# Altium schematic sheet VersaVIS.SchDoc
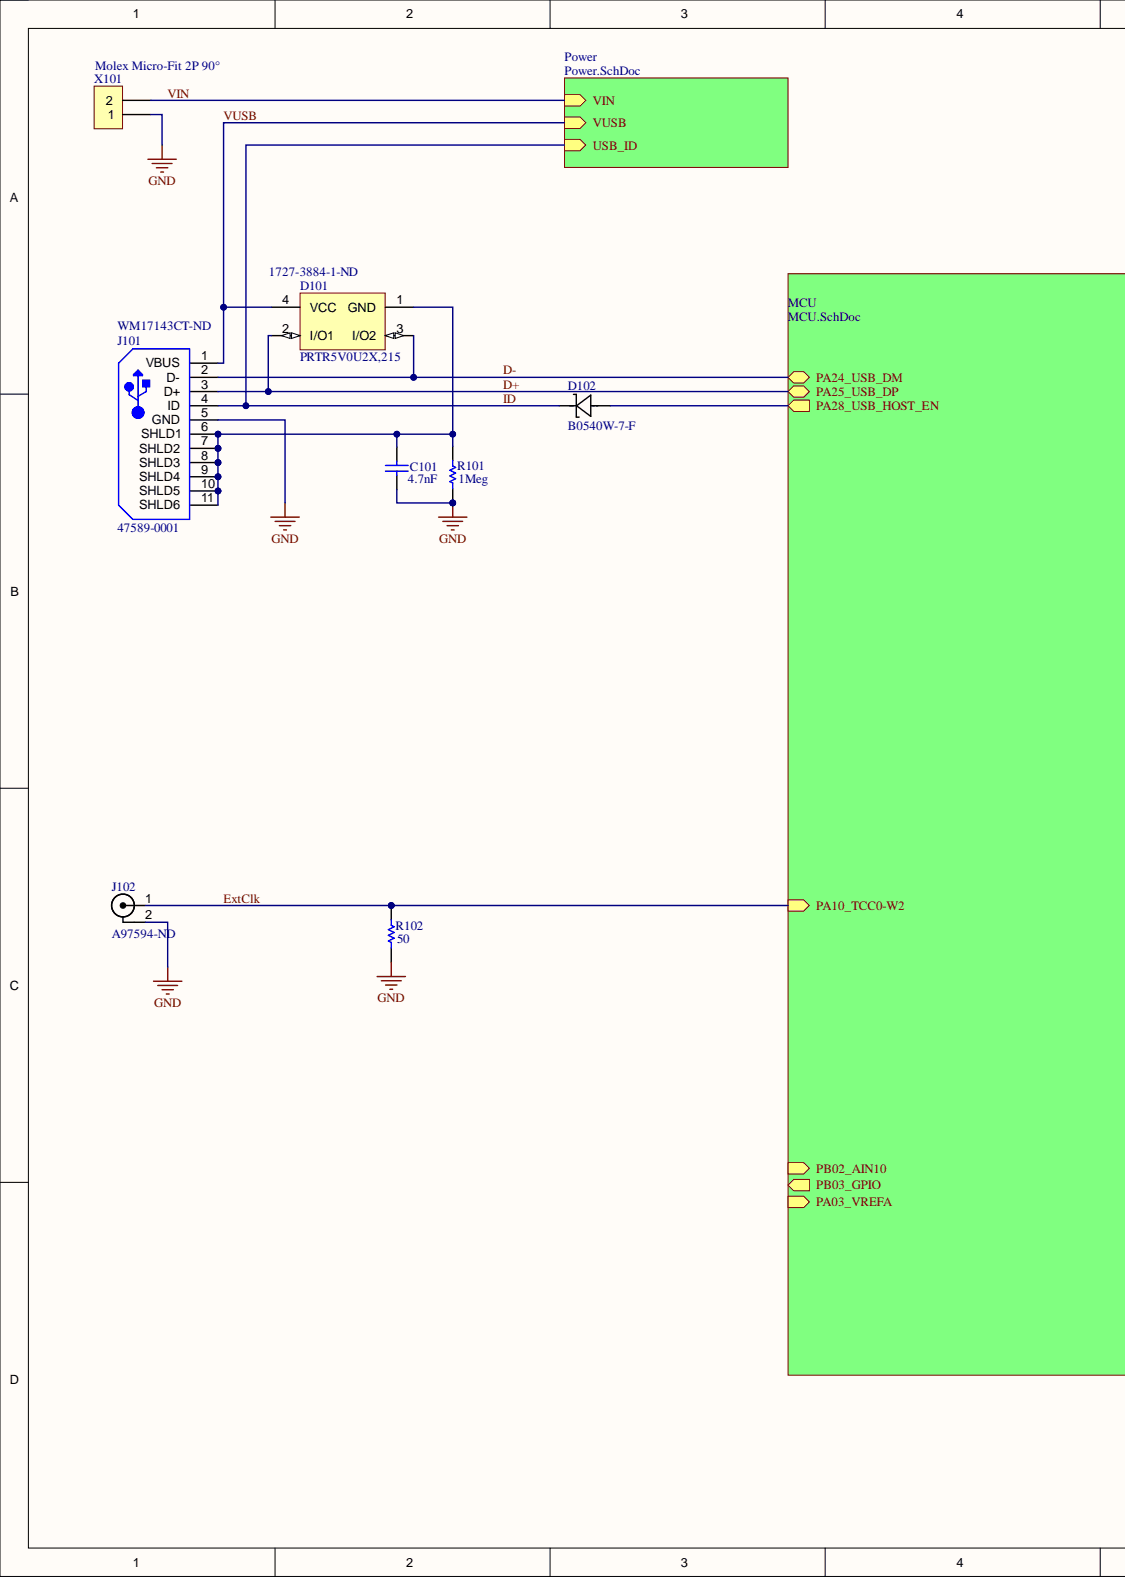
[diagram p0: VersaVIS.SchDoc, left]
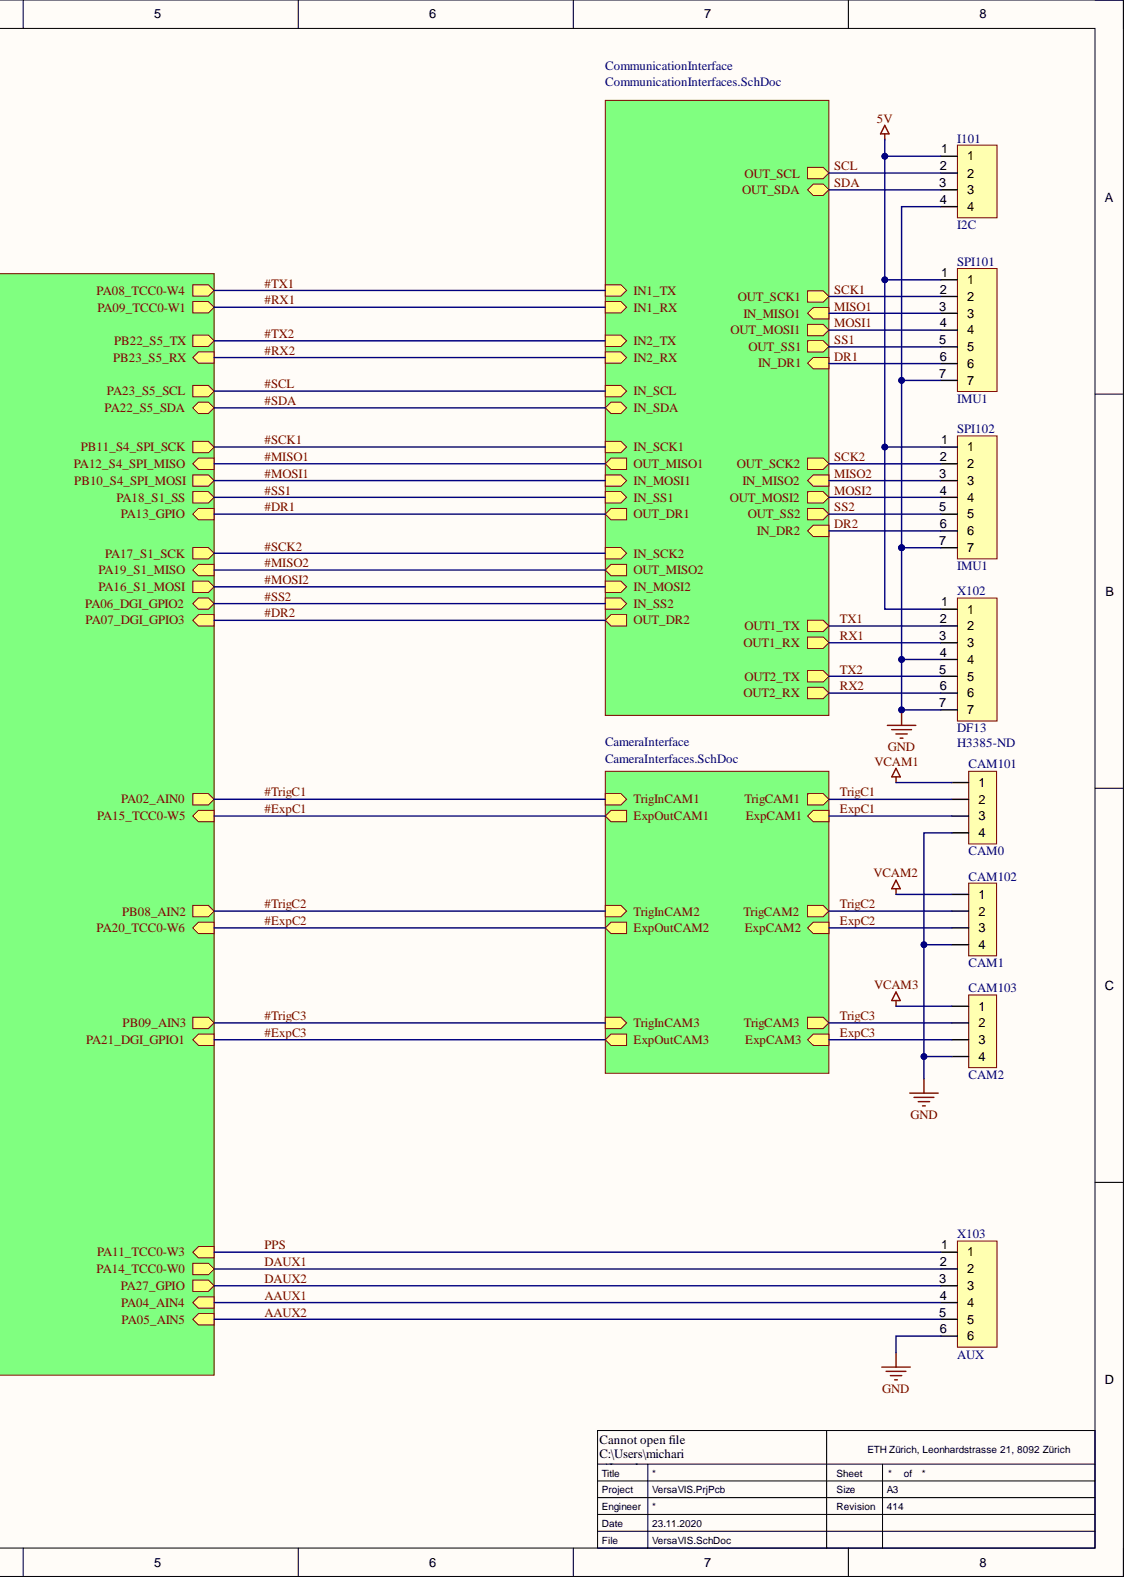
[diagram p1: VersaVIS.SchDoc, right]

## Parts
C101  value 4.7nF  [CAPC0603(1608)100_L]  (hidden comment: CAP 4.7nF 100V 0603(1608))
CAM101  CAM0  [MolexMicro-Fit 4P]
CAM102  CAM1  [MolexMicro-Fit 4P]
CAM103  CAM2  [MolexMicro-Fit 4P]
D101  PRTR5V0U2X,215  Supplier Part Number 1: 1727-3884-1-ND  [NXP-SOT143B-4_V]
D102  B0540W-7-F  [SOD123]
I101  I2C  [DF13_CONN_4P_90_SMT]
J101  47589-0001  Supplier Part Number 1: WM17143CT-ND  [MOLX-47589-0001_V]
J102  Supplier Part Number 1: A97594-ND  [SMA]  (hidden comment: SMA)
R101  value 1Meg  [RESC0603(1608)_N]  (hidden comment: 1M 5% 0603(1608))
R102  value 50  [RESC0603(1608)_N]  (hidden comment: 1M 5% 0603(1608))
SPI101  IMU1  [DF13_CONN_7P_90_SMT]
SPI102  IMU1  [DF13_CONN_7P_90_SMT]
X101  Molex Micro-Fit 2P 90°  [MolexMicro-Fit 2P 90°]
X102  DF13  [DF13_CONN_7P_90_SMT]
X103  AUX  [DF13_CONN_6P_90_SMT]

## Nets
5V: I101.1 SPI101.1 SPI102.1 X102.1
GND: C101.1 CAM101.4 CAM102.4 CAM103.4 I101.4 J101.5(GND) J102.2 R101.1 R102.1 SPI101.7 SPI102.7 X101.1 X102.4 X102.7 X103.6
VCAM1: CAM101.1
VCAM2: CAM102.1
VCAM3: CAM103.1
AAUX1: X103.4
AAUX2: X103.5
D+: D101.2(I/O1) J101.3(D+)
D-: D101.3(I/O2) J101.2(D-)
DAUX1: X103.2
DAUX2: X103.3
DR1: SPI101.6
DR2: SPI102.6
ExpC1: CAM101.3
ExpC2: CAM102.3
ExpC3: CAM103.3
ExtClk: J102.1 R102.2
ID: D102.1 J101.4(ID)
MISO1: SPI101.3
MISO2: SPI102.3
MOSI1: SPI101.4
MOSI2: SPI102.4
PPS: X103.1
RX1: X102.3
RX2: X102.6
SCK1: SPI101.2
SCK2: SPI102.2
SCL: I101.2
SDA: I101.3
SS1: SPI101.5
SS2: SPI102.5
TrigC1: CAM101.2
TrigC2: CAM102.2
TrigC3: CAM103.2
TX1: X102.2
TX2: X102.5
VIN: X101.2
VUSB: D101.4(VCC) J101.1(VBUS)
NetC101_2: C101.2 D101.1(GND) J101.6(SHLD1) J101.7(SHLD2) J101.8(SHLD3) J101.9(SHLD4) J101.10(SHLD5) J101.11(SHLD6) R101.2
NetD102_2: D102.2
#DR1:
#DR2:
#ExpC1:
#ExpC2:
#ExpC3:
#MISO1:
#MISO2:
#MOSI1:
#MOSI2:
#RX1:
#RX2:
#SCK1:
#SCK2:
#SCL:
#SDA:
#SS1:
#SS2:
#TrigC1:
#TrigC2:
#TrigC3:
#TX1:
#TX2:
PA03_VREFA:
PB02_AIN10:
PB03_GPIO:

## Library data of the parts
C101 = CAP: CAP 4.7nF 100V ±10% 0603 (1608 Metric) Thickness 1mm SMD; Case-EIA: 0603; Case-Metric: 1608; Tolerance: Â±10%; Component Kind: Standard; Max Thickness: 1 mm; Rated Voltage: 100 V; Supplier 1: Digi-Key; Supplier Part Number 1: 399-14206-1-ND; Technology: SMT; Library Name: VersaVIS.SCHLIB
CAM101-CAM103 = Molex Micro-Fit 4P 90°: PARTNR: 043045-0401; Component Kind: Standard; Description: Molex Micro-Fit 4P 90°; MANUFACTURER: Molex; Supplier 1: Digi-Key; Supplier Part Number 1: WM1814-ND; Library Name: VersaVIS.SCHLIB
D101 = NXP-PRTR5V0U2X,215-4: Ultra Low Capacitance Double Rail-to-Rail ESD Protection Diode, 5.5 V, 1 pF, -40 to 85 degC, 4-Pin SOT143B, RoHS, Tape and Reel; Manufacturer: NXP Semiconductors; Capacitance Value: 16pF; Configuration: Quad; Direction Type: Uni-Directional; ESD Protection Voltage: 8KV@ContactDisc; Leakage Current: 0.1uA; Number of Elements: 1; Product Depth (mm): 1.4mm; Product Height (mm): 1mm; Product Length (mm): 3mm; Rad Hardened: No; Reverse Breakdown Voltage: 6V; Reverse Stand-off Voltage: 5.5V; Suppressor Type: DiodeArrays; Component Kind: Standard; Datasheet URL: https://datasheet.ciiva.com/4479/prtr5v0u2x-102853-4479461.pdf; Datasheet Version: Rev. 02, 01/2008; Leakage Current (Max): 0.1uA; Manufacturer URL: http://www.nxp.com/; Mounting: SurfaceMount; Mounting Style: SurfaceMount; Operating Temp Range: -40Cto85C; Operating Temperature Classification: Industrial; Operating Temperature Max Deg. C: 85C; Operating Temperature Min Deg. C: -40C; Package / Case: SOT; Package Type: SOT; Packaging: TapeandReel; Pin Count: 3+Tab; Polarity: Uni-Directional; Supplier 1: Digi-Key; Working Voltage: 5.5V; Working Voltage (Max): 5.5V; Library Name: VersaVIS.SCHLIB
D102 = B0540W-7-F: Shottky Diode 60V500mA; Built_By: EMA_Anthony; Type: DEV; Vendor: Diodes Inc; Component Kind: Standard; Manufacturer_Part_Number: B0540W-7-F; RefDes: RefDes; Supplier 1: Digi-Key; Supplier Part Number 1: B0540W-FDICT-ND; Value: Value; Copyright: Copyright (C) 2016 Accelerated Designs. All rights reserved; Library Name: VersaVIS.SCHLIB
I101 = DF13_CONN_4P_90_SMD: Single Row Pin Header (SMD); MANUFACTURER: HIROSE; PARTNR: DF13A-7P-1.25H(50); Component Kind: Standard; Supplier 1: Digi-Key; Supplier Part Number 1: H125779CT-ND; Library Name: VersaVIS.SCHLIB
J101 = USB-5-6SHLD: Right Angle Female Type AB Micro USB Connector, 30 V, 1.8 A, -30 to 85 degC, RoHS, Tape and Reel; Brand: Molex; Connector Type: MicroUSBTypeABreceptacle; Current Rating: 1.8A; Number of Contacts: 5Contact; Number of Ports: 1Port; Voltage Rating: 30VAC; : 0475890001; Color: Black; Component Kind: Standard; Contact Material: CopperAlloy; Contact Plating: Gold; Datasheet URL: http://www.molex.com/webdocs/datasheets/pdf/en-us/0475890001_IO_CONNECTORS.pdf; Datasheet Version: 12/2016; Gender: Female; Manufacturer: Molex; Manufacturer URL: http://www.molex.com/; Mounting Angle: Right; Mounting Technology: SurfaceMount; Operating Temperature Range: -20Cto+85C; Package Description: Micro USB Connector, Pitch 0.65 mm, 5 Positions, Body 7.5 x 5.6 mm; Package Reference: 47589-0001; Package URL: http://www.molex.com/pdm_docs/sd/475890001_sd.pdf; Packaging: Reel; Product: MicroUSBTypeABConnectors; Product Category: USBConnectors; RoHS: True; Series: 47589; Standard: USB; Supplier 1: Digi-Key; Termination Style: SMD/SMT; Library Name: VersaVIS.SCHLIB
J102 = SMA: SMA connector; Component Kind: Standard; Supplier 1: Digi-Key; Library Name: VersaVIS.SCHLIB
R101 R102 = RES: 1M 0.1W 5% 0603 (1608 Metric)  SMD; Case-EIA: 0603; Case-Metric: 1608; Component Kind: Standard; Power: 0.1 W; Supplier 1: Digi-Key; Supplier Part Number 1: P1.0MGCT-ND; Technology: SMT; Tolerance: 5 %; Library Name: VersaVIS.SCHLIB
SPI101 SPI102 X102 = DF13_CONN_7P_90_SMD: Single Row Pin Header (SMD); MANUFACTURER: HIROSE; PARTNR: DF13A-7P-1.25H(50); Component Kind: Standard; Supplier 1: Digi-Key; Supplier Part Number 1: H3385-ND; Library Name: VersaVIS.SCHLIB
X101 = Molex Micro-Fit 2P 90°: Molex Micro-Fit 2P 0436500201; Component Kind: Standard; Supplier 1: Digi-Key; Supplier Part Number 1: WM1865-ND; Library Name: VersaVIS.SCHLIB
X103 = DF13_CONN_6P_90_SMD: Single Row Pin Header (SMD); MANUFACTURER: HIROSE; PARTNR: DF13A-7P-1.25H(50); Component Kind: Standard; Supplier 1: Digi-Key; Supplier Part Number 1: H125981CT-ND; Library Name: VersaVIS.SCHLIB
